# Altium schematic sheet powering.SchDoc(powering)
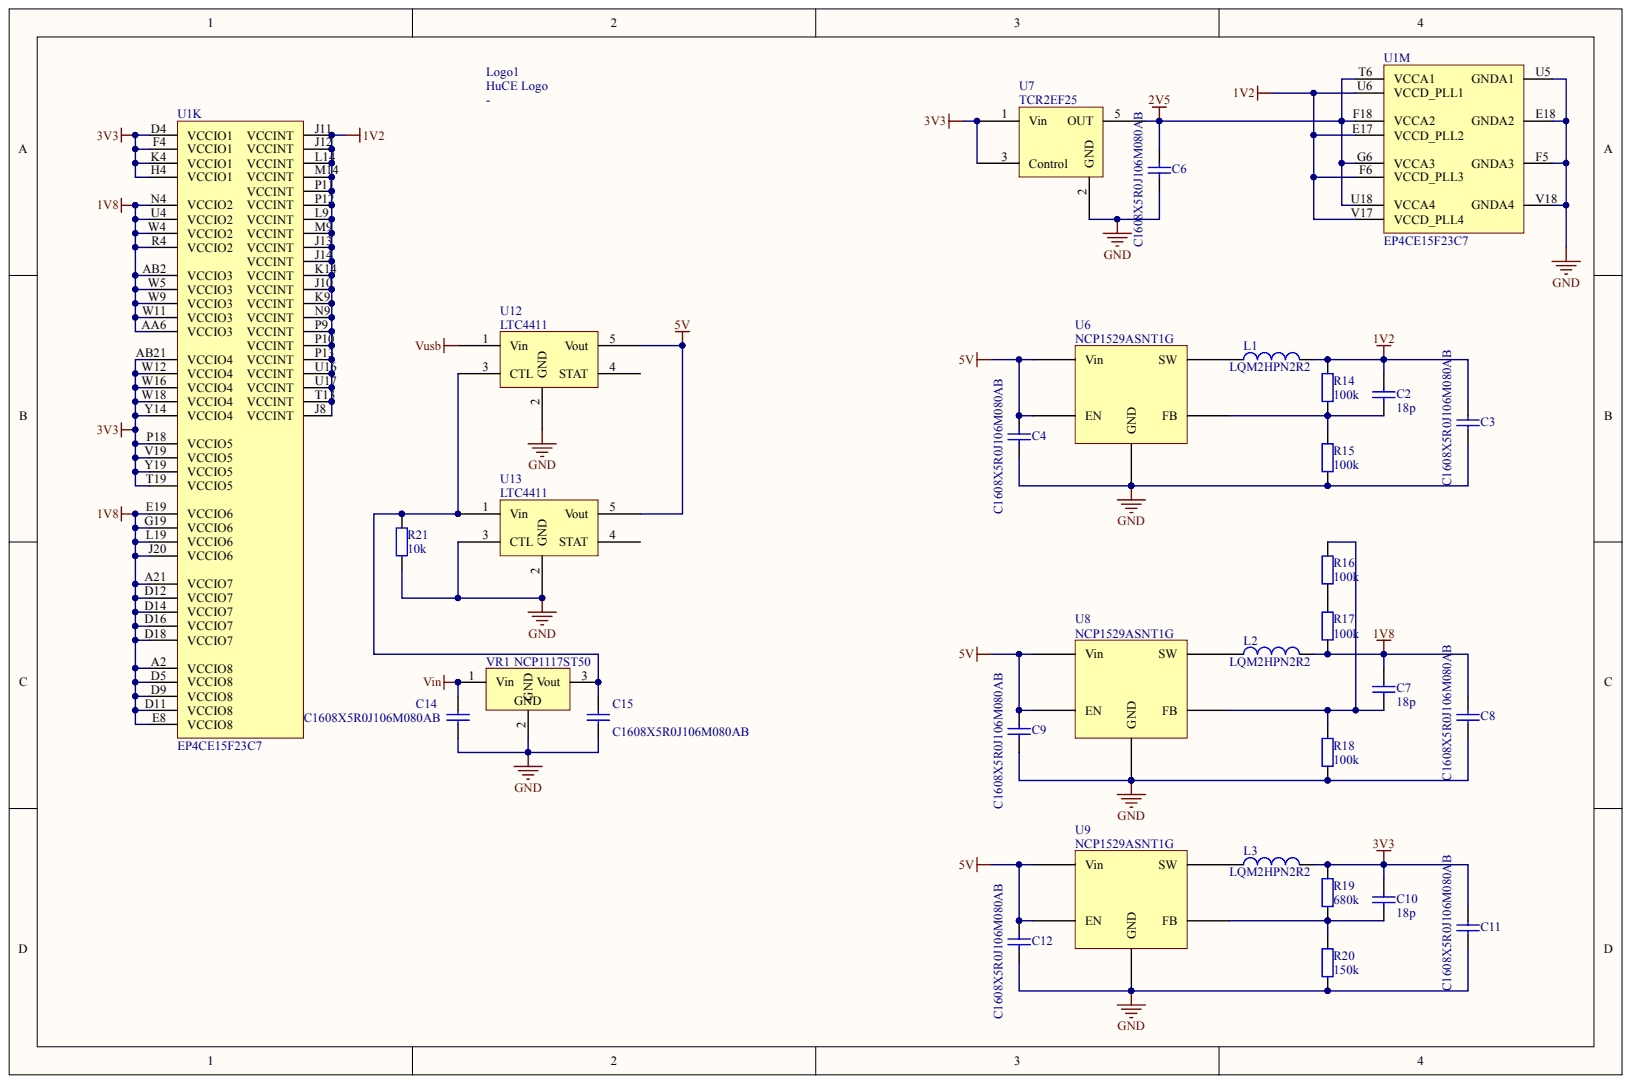
[diagram p0: powering.SchDoc]

## Parts
C2  18p  [CAPC1005[0402]X50]
C3  C1608X5R0J106M080AB  [CAPC1608X80]
C4  C1608X5R0J106M080AB  [CAPC1608X80]
C6  C1608X5R0J106M080AB  [CAPC1608X80]
C7  18p  [CAPC1005[0402]X50]
C8  C1608X5R0J106M080AB  [CAPC1608X80]
C9  C1608X5R0J106M080AB  [CAPC1608X80]
C10  18p  [CAPC1005[0402]X50]
C11  C1608X5R0J106M080AB  [CAPC1608X80]
C12  C1608X5R0J106M080AB  [CAPC1608X80]
C14  C1608X5R0J106M080AB  [CAPC1608X80]
C15  C1608X5R0J106M080AB  [CAPC1608X80]
L1  value LQM2HPN2R2  [CI1008]  (hidden comment: Inductor)
L2  value LQM2HPN2R2  [CI1008]  (hidden comment: Inductor)
L3  value LQM2HPN2R2  [CI1008]  (hidden comment: Inductor)
Logo1  -  [microLab]
R14  100k  [RESC1005[0402]X35]
R15  100k  [RESC1005[0402]X35]
R16  100k  [RESC1005[0402]X35]
R17  100k  [RESC1005[0402]X35]
R18  100k  [RESC1005[0402]X35]
R19  680k  [RESC1005[0402]X35]
R20  150k  [RESC1005[0402]X35]
R21  10k  [RESC1005[0402]X35]
U1  EP4CE15F23C7  [FBGA484_A-240]  (parts K,M)
U6  NCP1529ASNT1G  [SOT95P270X145-5N]
U7  TCR2EF25  [SOT95P270X145-5N]
U8  NCP1529ASNT1G  [SOT95P270X145-5N]
U9  NCP1529ASNT1G  [SOT95P270X145-5N]
U12  LTC4411  [SOT95P270X145-5N]
U13  LTC4411  [SOT95P270X145-5N]
VR1  NCP1117ST50  [SOT223_L]

## Nets
1V2: C2.2 C3.2 L1.2 R14.2 U1.E17(VCCD_PLL2) U1.F6(VCCD_PLL3) U1.J8(VCCINT) U1.J10(VCCINT) U1.J11(VCCINT) U1.J12(VCCINT) U1.J13(VCCINT) U1.J14(VCCINT) U1.K9(VCCINT) U1.K14(VCCINT) U1.L9(VCCINT) U1.L14(VCCINT) U1.M9(VCCINT) U1.M14(VCCINT) U1.N9(VCCINT) U1.P9(VCCINT) U1.P10(VCCINT) U1.P11(VCCINT) U1.P12(VCCINT) U1.P13(VCCINT) U1.T13(VCCINT) U1.U6(VCCD_PLL1) U1.U16(VCCINT) U1.U17(VCCINT) U1.V17(VCCD_PLL4)
1V8: C7.2 C8.2 L2.2 R17.1 U1.A2(VCCIO8) U1.A21(VCCIO7) U1.AA6(VCCIO3) U1.AB2(VCCIO3) U1.D5(VCCIO8) U1.D9(VCCIO8) U1.D11(VCCIO8) U1.D12(VCCIO7) U1.D14(VCCIO7) U1.D16(VCCIO7) U1.D18(VCCIO7) U1.E8(VCCIO8) U1.E19(VCCIO6) U1.G19(VCCIO6) U1.J20(VCCIO6) U1.L19(VCCIO6) U1.N4(VCCIO2) U1.R4(VCCIO2) U1.U4(VCCIO2) U1.W4(VCCIO2) U1.W5(VCCIO3) U1.W9(VCCIO3) U1.W11(VCCIO3)
2V5: C6.2 U1.F18(VCCA2) U1.G6(VCCA3) U1.T6(VCCA1) U1.U18(VCCA4) U7.5(OUT)
3V3: C10.2 C11.2 L3.2 R19.2 U1.AB21(VCCIO4) U1.D4(VCCIO1) U1.F4(VCCIO1) U1.H4(VCCIO1) U1.K4(VCCIO1) U1.P18(VCCIO5) U1.T19(VCCIO5) U1.V19(VCCIO5) U1.W12(VCCIO4) U1.W16(VCCIO4) U1.W18(VCCIO4) U1.Y14(VCCIO4) U1.Y19(VCCIO5) U7.1(Vin) U7.3(Control)
5V: C4.2 C9.2 C12.2 U6.1(EN) U6.4(Vin) U8.1(EN) U8.4(Vin) U9.1(EN) U9.4(Vin) U12.5(Vout) U13.5(Vout)
GND: C3.1 C4.1 C6.1 C8.1 C9.1 C11.1 C12.1 C14.1 C15.1 R15.1 R18.1 R20.1 R21.1 U1.E18(GNDA2) U1.F5(GNDA3) U1.U5(GNDA1) U1.V18(GNDA4) U6.2(GND) U7.2(GND) U8.2(GND) U9.2(GND) U12.2(GND) U13.2(GND) U13.3(CTL) VR1.2
VIN: C14.2 VR1.1
VUSB: U12.1(Vin)
NetC2_1: C2.1 R14.1 R15.2 U6.5(FB)
NetC7_1: C7.1 R16.2 R18.2 U8.5(FB)
NetC10_1: C10.1 R19.1 R20.2 U9.5(FB)
NetC15_2: C15.2 R21.2 U12.3(CTL) U13.1(Vin) VR1.3
NetL1_1: L1.1 U6.3(SW)
NetL2_1: L2.1 U8.3(SW)
NetL3_1: L3.1 U9.3(SW)
NetR16_1: R16.1 R17.2

Not connected: U12 4(STAT); U13 4(STAT)

## Library data of the parts
C2 C7 C10 = C: Capacitor; Modified_by: BEP1; Component Kind: Standard; Library Name: RC_SMD_3D.SchLib; Modified: 10.2008; Value: 18p
C3 C4 C6 C8 C9 C11 C12 C14 C15 = Cap: Capacitor; Component Kind: Standard; LatestRevisionDate: 17-Jul-2002; LatestRevisionNote: Re-released for DXP Platform.; Library Name: Miscellaneous Devices.IntLib; PackageDescription: Radial Cap, Thru-Hole; 2 Leads; 0.3 in Pin Spacing; PackageReference: RAD-0.3; Published: 8-Jun-2000; Signal Integrity: Cap; Simulation: CAP; Value: C1608X5R0J106M080AB; Publisher: Altium Limited
L1-L3 = Inductor: Code_IEC: 1005; Code_JEITA: 0402; Component Kind: Standard; Description: Inductor; LatestRevisionDate: 29-May-2009; LatestRevisionNote: IPC-7351 Footprint Added.; Library Name: Miscellaneous Devices.IntLib; PackageDescription: Chip Inductor; PackageReference: 0402-A; Published: 8-Jun-2000; Signal Integrity: Inductor; Simulation: INDUCTOR; Publisher: Altium Limited
Logo1 = HuCE Logo: Dummy for Logo Footprint; Component Kind: Standard; Library Name: HuCE_Logo.SchLib
R14-R21 = R: Resistor; Modified_by: BEP1; Component Kind: Standard; Library Name: RC_SMD_3D.SchLib; Modified: 10.2008
  R14-R18: Value: 100k
  R19: Value: 680k
  R20: Value: 150k
  R21: Value: 10k
U1 = EP4CE15F23C7: Cyclone IV Family FPGA, 1.2V Core, 343 I/O Pins, 4 PLLs, 484-Pin FBGA, Speed Grade 7, Commercial Grade; Manufacturer: Altera; Code_JEDEC: MS-034-AAJ-1; Component Kind: Standard; ComponentLink1Description: Manufacturer URL; ComponentLink1URL: http://www.altera.com/; ComponentLink2Description: Handbook; ComponentLink2URL: http://www.altera.com/literature/hb/cyclone-iv/cyclone4-handbook.pdf; ComponentLink3Description: Pinout; ComponentLink3URL: http://www.altera.com/literature/lit-dp.jsp?category=cyc%204&showspreadsheet=y; ComponentLink4Description: Package Specification; ComponentLink4URL: http://www.altera.com/devicepackaging/04R00247-02.pdf; DatasheetVersion: ver1.6, Nov-2011; Library Name: Altera Cyclone IV E.IntLib; PackageDescription: 484-Pin FineLine Ball Grid Array (FBGA) - Wire Bond - A:2.40; PackageReference: FBGA484_A-240; PackageVersion: ver1.0, Jun-2011
U6 U8 U9 = Component_1: Component Kind: Standard; Library Name: ncp1529.SchLib; VALUE: NCP1529ASNT1G
U7 = TCR2EF: Component Kind: Standard; VALUE: TCR2EF25; Library Name: geckoedu.SchLib
VR1 = Volt Reg: Voltage Regulator; Component Kind: Standard; LatestRevisionDate: 29-May-2009; LatestRevisionNote: IPC-7351 Footprint Added.; Library Name: Miscellaneous Devices.IntLib; PackageDescription: 3-Pin Transistor Outline Package 2.54 mm Pitch, 15.1 mm Lead Span; PackageReference: D2PAK; Published: 24-Mar-1999; VALUE: NCP1117ST50; Publisher: Altium Limited
U12 U13 = LTC4411: Component Kind: Standard; VALUE: LTC4411; Library Name: geckoedu.SchLib
